# Altium schematic sheet RF19_Sensor_CAN_Module_Interfaces.SchDoc
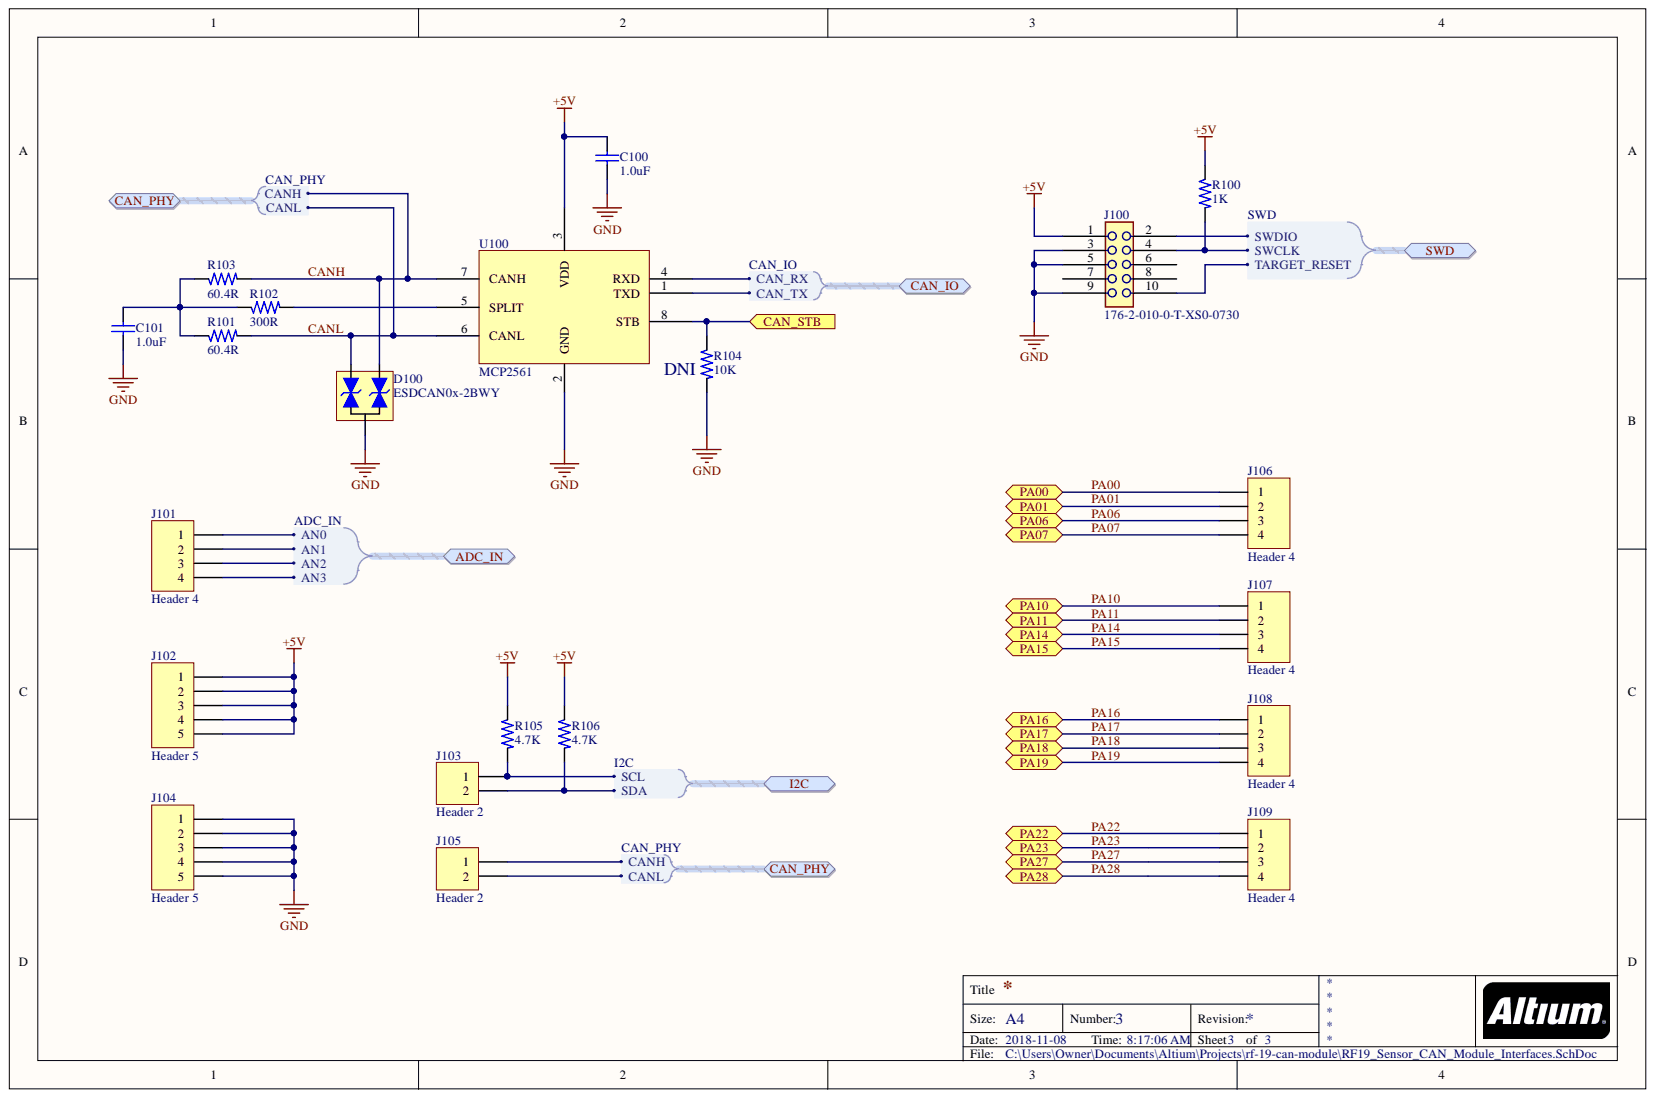
[diagram p0: RF19_Sensor_CAN_Module_Interfaces.SchDoc]

## Parts
C100  value 1.0uF  [C0402]  (hidden comment: CAP CERAMIC)
C101  value 1.0uF  [C0402]  (hidden comment: CERAMIC CAP)
D100  ESDCAN0x-2BWY  [SOT323-3L]
J100  MPN: 176-2-010-0-T-XS0-0730  [5X2 HEADER]
J101  Header 4  [HDR1X4]
J102  Header 5  [HDR1X5]
J103  Header 2  [HDR1X2]
J104  Header 5  [HDR1X5]
J105  Header 2  [HDR1X2]
J106  Header 4  [HDR1X4]
J107  Header 4  [HDR1X4]
J108  Header 4  [HDR1X4]
J109  Header 4  [HDR1X4]
R100  value 1K  [R0402]  (hidden comment: RESISTOR)
R101  value 60.4R  [R0402]  (hidden comment: RESISTOR)
R102  value 300R  [R0402]  (hidden comment: RESISTOR)
R103  value 60.4R  [R0402]  (hidden comment: RESISTOR)
R104  value 10K  [R0402]  (hidden comment: RESISTOR)
R105  value 4.7K  [R0402]  (hidden comment: RESISTOR)
R106  value 4.7K  [R0402]  (hidden comment: RESISTOR)
U100  MCP2561  [SOIC127P600X175-8N]

## Ports
ADC_IN, ADC_IN.AN0, ADC_IN.AN1, ADC_IN.AN2, ADC_IN.AN3, CAN_IO, CAN_IO.CAN_RX, CAN_IO.CAN_TX, CAN_PHY, CAN_PHY.CANH, CAN_PHY.CANL, CAN_STB, I2C, I2C.SCL, I2C.SDA, PA00, PA01, PA06, PA07, PA10, PA11, PA14, PA15, PA16, PA17, PA18, PA19, PA22, PA23, PA27, PA28, SWD, SWD.SWCLK, SWD.SWDIO, SWD.TARGET_RESET

## Nets
+5V: C100.1 J100.1 J102.1 J102.2 J102.3 J102.4 J102.5 R100.1 R105.1 R106.1 U100.3(VDD)
GND: C100.2 C101.2 D100.3 J100.3 J100.5 J100.9 J104.1 J104.2 J104.3 J104.4 J104.5 R104.2 U100.2(GND)
CAN_STB: R104.1 U100.8(STB) ; port CAN_STB
CANH: D100.1 J105.1 R103.2 U100.7(CANH) ; port CAN_PHY
CANL: D100.2 J105.2 R101.2 U100.6(CANL) ; port CAN_PHY
PA00: J106.1 ; port PA00
PA01: J106.2 ; port PA01
PA06: J106.3 ; port PA06
PA07: J106.4 ; port PA07
PA10: J107.1 ; port PA10
PA11: J107.2 ; port PA11
PA14: J107.3 ; port PA14
PA15: J107.4 ; port PA15
PA16: J108.1 ; port PA16
PA17: J108.2 ; port PA17
PA18: J108.3 ; port PA18
PA19: J108.4 ; port PA19
PA22: J109.1 ; port PA22
PA23: J109.2 ; port PA23
PA27: J109.3 ; port PA27
PA28: J109.4 ; port PA28
NetC101_1: C101.1 R101.1 R102.1 R103.1
NetJ100_2: J100.2 ; port SWD
NetJ100_4: J100.4 R100.2 ; port SWD
NetJ100_10: J100.10 ; port SWD
NetJ101_1: J101.1 ; port ADC_IN
NetJ101_2: J101.2 ; port ADC_IN
NetJ101_3: J101.3 ; port ADC_IN
NetJ101_4: J101.4 ; port ADC_IN
NetJ103_1: J103.1 R105.2 ; port I2C
NetJ103_2: J103.2 R106.2 ; port I2C
NetR102_2: R102.2 U100.5(SPLIT)
NetU100_1: U100.1(TXD) ; port CAN_IO
NetU100_4: U100.4(RXD) ; port CAN_IO

Not connected: J100 6 7 8

## Library data of the parts
C100 = CAP CERAMIC: Ceramic capacitor; Component Kind: Standard; Library Name: Custom.SchLib
C101 = CERAMIC CAP: Ceramic Capacitor; Component Kind: Standard; Library Name: Custom.SchLib; Supplier 1: Digi-Key; Supplier Part Number 1: 311-1802-1-ND
D100 = ESDCAN0x-2BWY: Dual-line Transil TVS diodes for CAN bus; Component Kind: Standard; Library Name: Custom.SchLib
J100 = A08-0385: 2x5 pin header, 1.27mm pitch, THM; Manufacturer: Garry; PartGroup: Mechanical devices,Connectors,Pin headers; Database Table Name: AltiumComponents; Value: PIN HEADER 2x5; AN: A08-0385; Component Kind: Standard; Height: 7,30000019073486; Library Name: AltiumDbLib.DbLib
J101 J106-J109 = Header 4: Header, 4-Pin; Component Kind: Standard; LatestRevisionDate: 17-Jul-2002; LatestRevisionNote: Re-released for DXP Platform.; Library Name: Miscellaneous Connectors.IntLib; Signal Integrity: Connector; Publisher: Altium Limited
J102 J104 = Header 5: Header, 5-Pin; Component Kind: Standard; LatestRevisionDate: 17-Jul-2002; LatestRevisionNote: Re-released for DXP Platform.; Library Name: Miscellaneous Connectors.IntLib; Signal Integrity: Connector; Publisher: Altium Limited
J103 J105 = Header 2: Header, 2-Pin; Component Kind: Standard; LatestRevisionDate: 17-Jul-2002; LatestRevisionNote: Re-released for DXP Platform.; Library Name: Miscellaneous Connectors.IntLib; Signal Integrity: Connector; Publisher: Altium Limited
U100 = MCP2561: High Speed CAN Transceiver; Component Kind: Standard; Library Name: Sensor module used symbols.SchLib
R100-R106 = RESISTOR: Component Kind: Standard; Description: Resistor; Library Name: Custom.SchLib
